# Altium schematic sheet PAN1070UA2A.SchDoc(PAN1070UA2A)
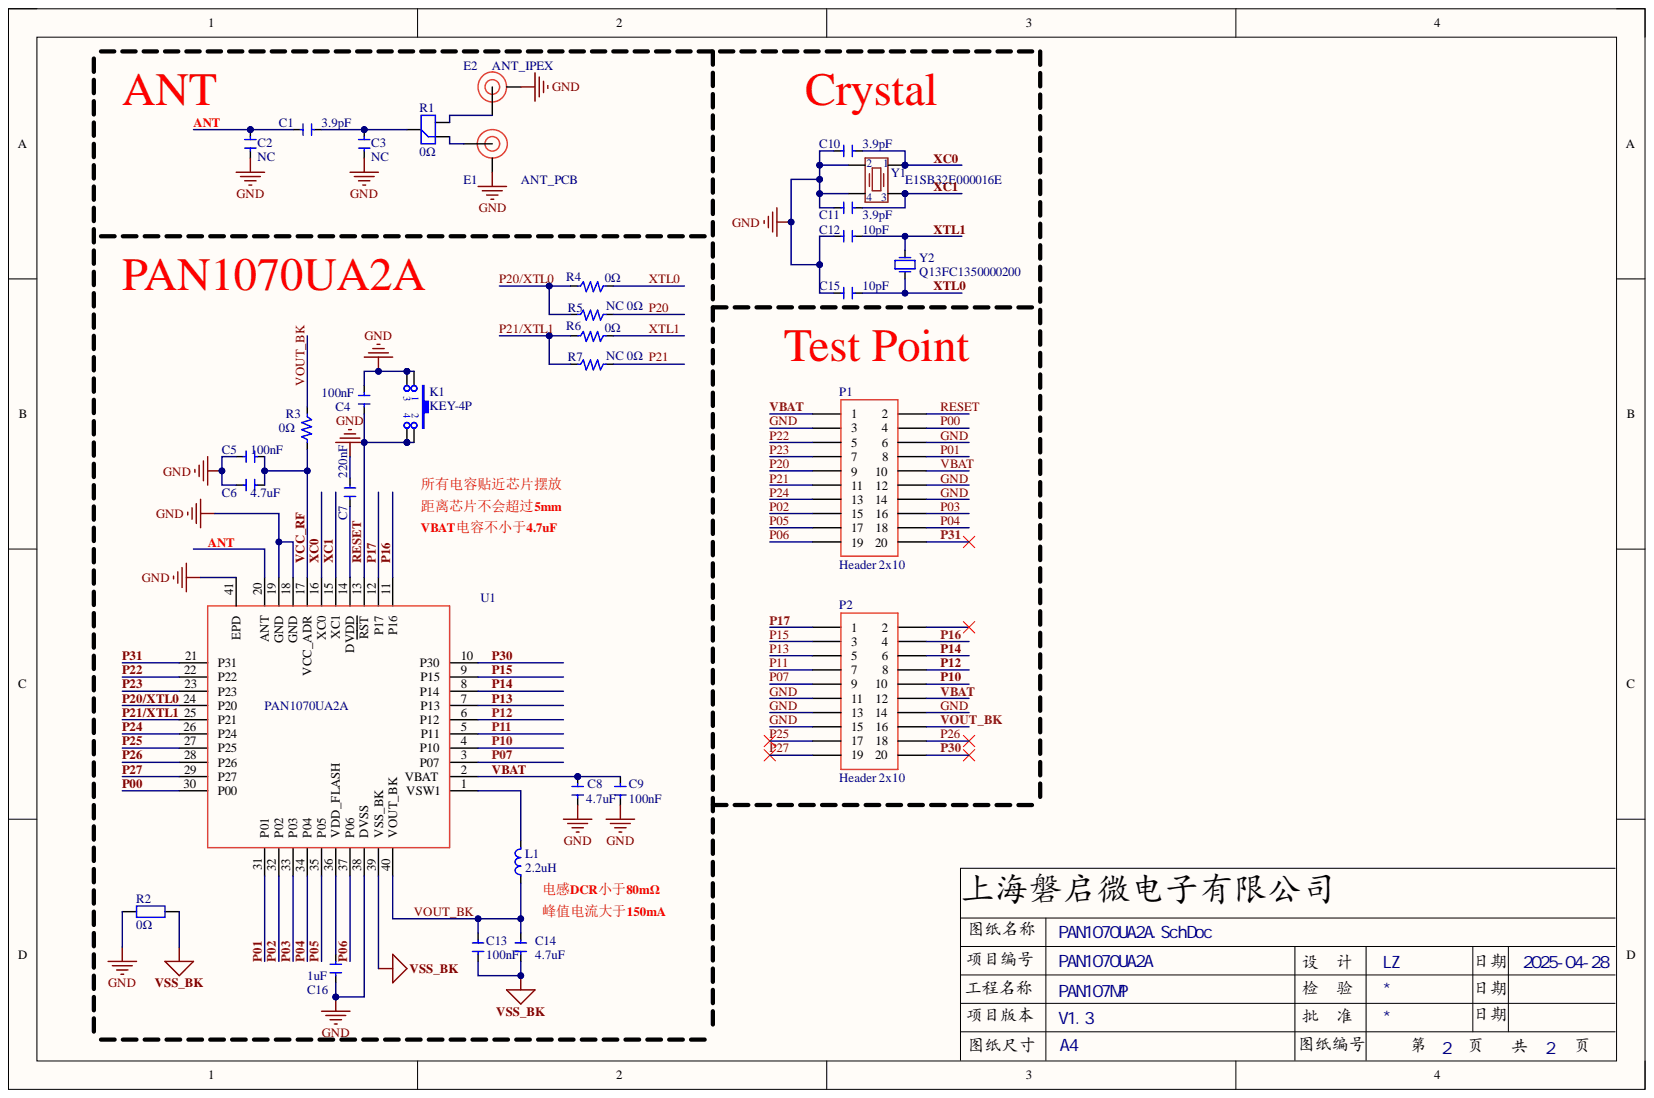
[diagram p0: PAN1070UA2A.SchDoc]

## Parts
C1  3.9pF  [0402_R_RF]
C2  NC  [0402_C_RF]
C3  NC  [0402_C_RF]
C4  100nF  [0402C_L]
C5  100nF  [0402C_L]
C6  4.7uF  [0402C_L]
C7  220nF  [0402C_L]
C8  4.7uF  [0402C_L]
C9  100nF  [0402C_L]
C10  3.9pF  [0402C_L]
C11  3.9pF  [0402C_L]
C12  10pF  [0402C_L]
C13  100nF  [0402C_L]
C14  4.7uF  [0402C_L]
C15  10pF  [0402C_L]
C16  1uF  [0402C_L]
E1  ANT_PCB  [2.4G_PF_SUB1.5mm_ADD1]
E2  ANT_IPEX  [ANT IPEX-2]
K1  KEY-4P  [SW-SMD(4.2X3.25X2.5)]
L1  2.2uH  [SMD-2520-1.2]
P1  Header 2x10  [HEADER 2X10]
P2  Header 2x10  [HEADER 2X10]
R1  0Ω  [0402R-3P_L]
R2  0Ω  [0402_R]
R3  0Ω  [0402R_L]
R4  0Ω  [0402R_L]
R5  NC 0Ω  [0402R_L]
R6  0Ω  [0402R_L]
R7  NC 0Ω  [0402R_L]
U1  PAN1070UA2A  [QFN40_5X5_P04]
Y1  E1SB32E000016E  [SMD-3225_4P]
Y2  Q13FC1350000200  [SMD-3215_2P FC - 135]

## Nets
VSS_BK: C13.1 C14.1 R2.2 U1.39(VSS_BK)
ANT: C1.1 C2.2 U1.20(ANT)
GND: C2.1 C3.1 C4.1 C5.1 C6.1 C7.1 C8.1 C9.1 C10.1 C11.1 C12.2 C15.2 C16.1 E1.2 E2.2 K1.1 K1.3 P1.3 P1.6 P1.12 P1.14 P2.11 P2.13 P2.14 P2.15 R2.1 U1.18(GND) U1.19(GND) U1.38(DVSS) U1.41(EPD) Y1.2 Y1.4
P00: P1.4 U1.30(P00)
P01: P1.8 U1.31(P01)
P02: P1.15 U1.32(P02)
P03: P1.16 U1.33(P03)
P04: P1.18 U1.34(P04)
P05: P1.17 U1.35(P05)
P06: P1.19 U1.37(P06)
P07: P2.9 U1.3(P07)
P10: P2.10 U1.4(P10)
P11: P2.7 U1.5(P11)
P12: P2.8 U1.6(P12)
P13: P2.5 U1.7(P13)
P14: P2.6 U1.8(P14)
P15: P2.3 U1.9(P15)
P16: P2.4 U1.11(P16)
P17: P2.1 U1.12(P17)
P20: P1.9 R5.1
P20/XTL0: R4.2 R5.2 U1.24(P20)
P21: P1.11 R7.1
P21/XTL1: R6.2 R7.2 U1.25(P21)
P22: P1.5 U1.22(P22)
P23: P1.7 U1.23(P23)
P24: P1.13 U1.26(P24)
P25: P2.17 U1.27(P25)
P26: P2.18 U1.28(P26)
P27: P2.19 U1.29(P27)
P30: P2.20 U1.10(P30)
P31: P1.20 U1.21(P31)
RESET: C4.2 K1.2 K1.4 P1.2 U1.13(RST)
VBAT: C8.2 C9.2 P1.1 P1.10 P2.12 U1.2(VBAT)
VCC_RF: C5.2 C6.2 R3.1 U1.17(VCC_ADR)
VOUT_BK: C13.2 C14.2 L1.1 P2.16 R3.2 U1.40(VOUT_BK)
XC0: C10.2 U1.16(XC0) Y1.1
XC1: C11.2 U1.15(XC1) Y1.3
XTL0: C15.1 R4.1 Y2.2
XTL1: C12.1 R6.1 Y2.1
NetC1_2: C1.2 C3.2 R1.1
NetC7_2: C7.2 U1.14(DVDD)
NetC16_2: C16.2 U1.36(VDD_FLASH)
NetE1_1: E1.1 R1.2
NetE2_1: E2.1 R1.3
NetL1_2: L1.2 U1.1(VSW1)

Not connected: P2 2

## Library data of the parts
C1-C5 C7 C9-C13 C15 C16 = CAP_Ceramic: 贴片陶瓷电容; Component Kind: Standard; Library Name: Panchip Library.IntLib
C6 C8 C14 = CAP_Ceramic: 贴片陶瓷电容; Parameter 1: C168172; Component Kind: Standard; Library Name: Panchip Library.IntLib
E1 = ANT_SMA: 180度横插式 3Pin SMA; Component Kind: Standard; Library Name: Panchip Library.IntLib
E2 = ANT_IPEX: Parameter 1: C2894919; Component Kind: Standard; Description: ANT_IPEX; Library Name: Panchip Library.IntLib
K1 = KEY-4P: 贴片4脚触摸按键; Parameter 1: C92589; Component Kind: Standard; Library Name: SWITCH-KEY.SchLib
L1 = Inductor_Power: 贴片功率电感; Component Kind: Standard; 立创编号: C2986792; Library Name: Panchip Library.IntLib
P1 P2 = Header 2x10: Header 2x10,2.54mm; Component Kind: Standard; Library Name: Panchip Library.IntLib
R1 = Resistor-3P: 贴片电阻; Component Kind: Standard; Library Name: Panchip Library.IntLib
R2 = 0402 0Ω (0R0) 1%: 贴片电阻; Component Kind: Standard; ComponentLink1Description: 供应商链接; ComponentLink1URL: http://www.szlcsc.com/product/details_17853.html; Library Name: Miscellaneous Devices LC.IntLib; Package: 0402; Supplier: LC; SuppliersPartNumber: C17168
R3-R7 = Resistor1: 贴片电阻; Component Kind: Standard; Library Name: Panchip Library.IntLib
U1 = PAN107MP_QFN40: BLE SOC; Component Kind: Standard; Library Name: Panchip Library.IntLib
Y1 = Crystal 4pin: 贴片4脚晶振; 型号: C427552; Component Kind: Standard; Library Name: Panchip Library.IntLib
Y2 = Crystal 2pin: 贴片2脚晶振; Parameter 1: C48615; Component Kind: Standard; Library Name: Panchip Library.IntLib
